# Altium schematic sheet Main.SchDoc
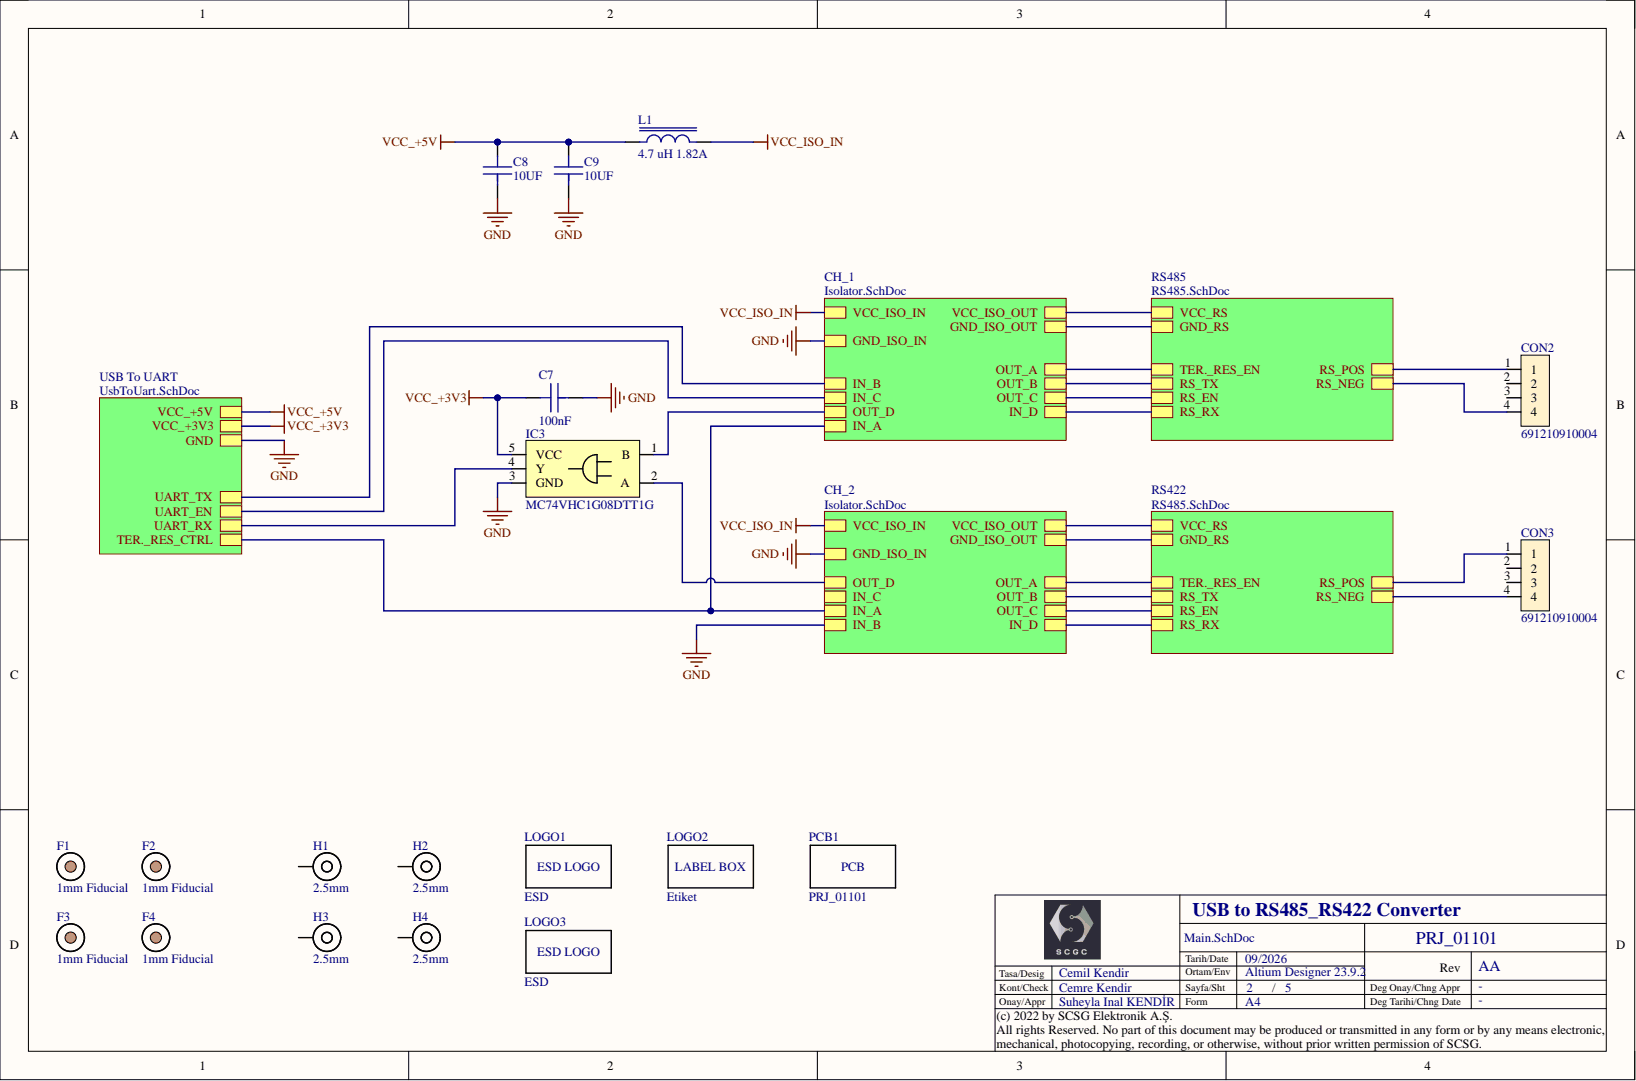
[diagram p0: Main.SchDoc]

## Parts
C7  100nF  [C0603]
C8  10UF  [c1206]
C9  10UF  [c1206]
CON2  691210910004  [691210910004]
CON3  691210910004  [691210910004]
F1  1mm Fiducial  [1mm Fiducial]  (no BOM)
F2  1mm Fiducial  [1mm Fiducial]  (no BOM)
F3  1mm Fiducial  [1mm Fiducial]  (no BOM)
F4  1mm Fiducial  [1mm Fiducial]  (no BOM)
H1  2.5mm  [2.5mm hole]  (no BOM)
H2  2.5mm  [2.5mm hole]  (no BOM)
H3  2.5mm  [2.5mm hole]  (no BOM)
H4  2.5mm  [2.5mm hole]  (no BOM)
IC3  MC74VHC1G08DTT1G  [SOT23-5]
L1  4.7 uH 1.82A  Current Rating: 1.82A  [WE-PD2_4532]
LOGO1  ESD  Sub-Category: LOGO  [ESD]  (no BOM)
LOGO2  Etiket  [ETIKET]  (no BOM)
LOGO3  ESD  Sub-Category: LOGO  [ESD]  (no BOM)
PCB1  PRJ_01101  [PCB]

## Nets
GND: C7.1 C8.1 C9.1 IC3.3(GND)
VCC_+3V3: C7.2 IC3.5(VCC)
VCC_+5V: C8.2 C9.2 L1.1
VCC_ISO_IN: L1.2
NetCON2_1: CON2.1
NetCON2_2: CON2.2
NetCON2_3: CON2.3
NetCON2_4: CON2.4
NetCON3_1: CON3.1
NetCON3_2: CON3.2
NetCON3_3: CON3.3
NetCON3_4: CON3.4
NetH1_1: H1.1
NetH2_1: H2.1
NetH3_1: H3.1
NetH4_1: H4.1
NetIC3_1: IC3.1(B)
NetIC3_2: IC3.2(A)
NetIC3_4: IC3.4(Y)
GND_RS:
IN_C:
OUT_A:
OUT_B:
OUT_C:
RS_RX:
TER._RES_CTRL:
UART_EN:
UART_TX:
VCC_RS:

## Library data of the parts
C7 = CC0603KRX7R8BB104: 100nF, 25V, X7R, 0603; Manufacturer: Yageo; Dielectric Material: Ceramic; Purpose Of Usage: BASIC; Tolerance: 10%; Value: 100000; Working Voltage: 0; Case Code (Metric): 1608; Case Code (Imperial): 0603; Case/Package: 0603; Category: CAPASITOR; Component Kind: Standard; Dielectric: X7R; Height: 0.8mm; Library Name: Capacitor.IntLib; Local Supplier: GENERAL; Manufacturer Part Number: CC0603KRX7R8BB104; Max Operating Temperature: 125°C; Min Operating Temperature: -55°C; Mount: SMD; Price 10K: 0.00250; RoHS: YES; Stock ID: ??; Sub-Category: CERAMIC; Supplier 1: Digi-Key; Supplier Part Number 1: 311-1341-1-ND; Voltage Rating: 25V; Voltage Rating (DC): 25V
C8 C9 = CL31B106MOHNNNE: 10UF, 16V, X7R, 1206; Manufacturer: Samsung; Dielectric Material: Ceramic; Purpose Of Usage: BASIC; Tolerance: 20%; Value: 10000000; Working Voltage: 0; Case Code (Metric): 3216; Case Code (Imperial): 1206; Case/Package: 1206; Category: CAPASITOR; Component Kind: Standard; Dielectric: X7R; Library Name: Capacitor.IntLib; Local Supplier: GENERAL; Manufacturer Part Number: CL31B106MOHNNNE; Max Operating Temperature: 125°C; Min Operating Temperature: -55°C; Mount: SMD; Price 10K: 0.00250; RoHS: YES; Stock ID: ??; Sub-Category: CERAMIC; Supplier 1: Digi-Key; Supplier Part Number 1: 1276-6641-1-ND; Voltage Rating: 16V; Voltage Rating (DC): 16V
CON2 CON3 = 691210910004: WR-TBL Terminal block - Rising Cage - THT; Manufacturer: Wurth Elektronik; Current Rating: 6A; Gender: Male; Number of Contacts: 4; Pitch: 2.54mm; Purpose Of Usage: BASIC; Category: CONNECTORS; Color: Green; Component Kind: Standard; Length: 10.56mm; Library Name: Connectors.IntLib; Local Supplier: WURTH; Manufacturer Part Number: 691210910004; Max Operating Temperature: 105°C; Min Operating Temperature: -40°C; Mount: SMD; RoHS: Compliant; Stock ID: ??; Sub-Category: TERMINAL BLOCK; Supplier 1: Digi-Key; Supplier Part Number 1: 732-691210910004-ND
F1-F4 = 1mm Fiducial: Manufacturer Part Number: Fiducial 2mm; Purpose Of Usage: BASIC; Category: MECHANICAL; Description: 1mm Fiducial; Library Name: Mechanical.IntLib; Manufacturer: --; Mount: NO MOUNT; Stock ID: ??; Sub-Category: FIDUCIAL
H1-H4 = 2.5 mm Hole: 2.5mm hole; Purpose Of Usage: BASIC; Category: MECHANICAL; Library Name: Mechanical.IntLib; Manufacturer: --; Manufacturer Part Number: --; Mount: NO MOUNT; Stock ID: ??; Sub-Category: HOLE
IC3 = MC74VHC1G08DTT1G: MC74VHC1G08 Series 2 to 5.5 SMT Single 2 Input AND Gate - SOT-23-5; Manufacturer: ON Semiconductor; Max Supply Voltage: 5.5V; Min Supply Voltage: 2V; Purpose Of Usage: BASIC; Case/Package: SOT-23-5; Category: INTEGRATED CIRCUITS; Component Kind: Standard; Lead Free: Lead Free; Library Name: IntegratedCircuit.IntLib; Manufacturer Part Number: MC74VHC1G08DTT1G; Max Operating Temperature: 125°C; Min Operating Temperature: -55°C; Mount: SMD; MSL: 1; Package Quantity: 1; RoHS: YES; Stock ID: ??; Sub-Category: LOGIC; Supplier 1: Digi-Key; Supplier Part Number 1: MC74VHC1G08DTT1GOSTR-ND
L1 = 744773047: WE-PD2, Unshielded Wire-wound SMD Inductor With Ferrite Core, 4.7 Uh, 1.82A I; Manufacturer: Wurth Elektronik; DC Resistance (DCR): 59mΩ; Saturation Current: 2.9A; Self Resonant Frequency: 45MHz; Test Frequency: 10kHz; Value: 4.7µH; Inductance Tolerance: 20%; Case/Package: SMD/SMT; Category: INDUCTORS; Component Kind: Standard; Height: 3.2mm; Length: 4mm; Library Name: Inductor.IntLib; Manufacturer Part Number: 744773047; Max DC Current: 1.82A; Max Operating Temperature: 125°C; Min Operating Temperature: -40°C; Mount: SMD; RMS Current (Irms): 1.82A; RoHS: YES; Shielding: Unshielded; Stock ID: ??; Sub-Category: FIXED INDUCTORS; Supplier 1: Digi-Key; Supplier Part Number 1: 732-1252-1-ND
LOGO1 LOGO3 = ESD: ESD LOGO; Purpose Of Usage: BASIC; Category: MECHANICAL; Library Name: Mechanical.IntLib; Manufacturer: --; Manufacturer Part Number: ESD; Mount: NO MOUNT; Stock ID: ??
LOGO2 = Etiket: Etiket kutu; Purpose Of Usage: BASIC; Category: MECHANICAL; Library Name: Mechanical.IntLib; Manufacturer: --; Manufacturer Part Number: Etiket; Mount: NO MOUNT; Stock ID: ??; Sub-Category: LOGO
PCB1 = PCB: Manufacturer: CUSTOM; Purpose Of Usage: BASIC; Category: MECHANICAL; Component Kind: Standard; Description: PCB; Library Name: Mechanical.SchLib; Local Supplier: CUSTOM; Manufacturer Part Number: CUSTOM; Mount: MECH; Price 10K: 0.25; Stock ID: ??; Sub-Category: PCB; Supplier 1: CUSTOM; Supplier Part Number 1: CUSTOM
